# Altium schematic sheet ContollerRMC.SchDoc
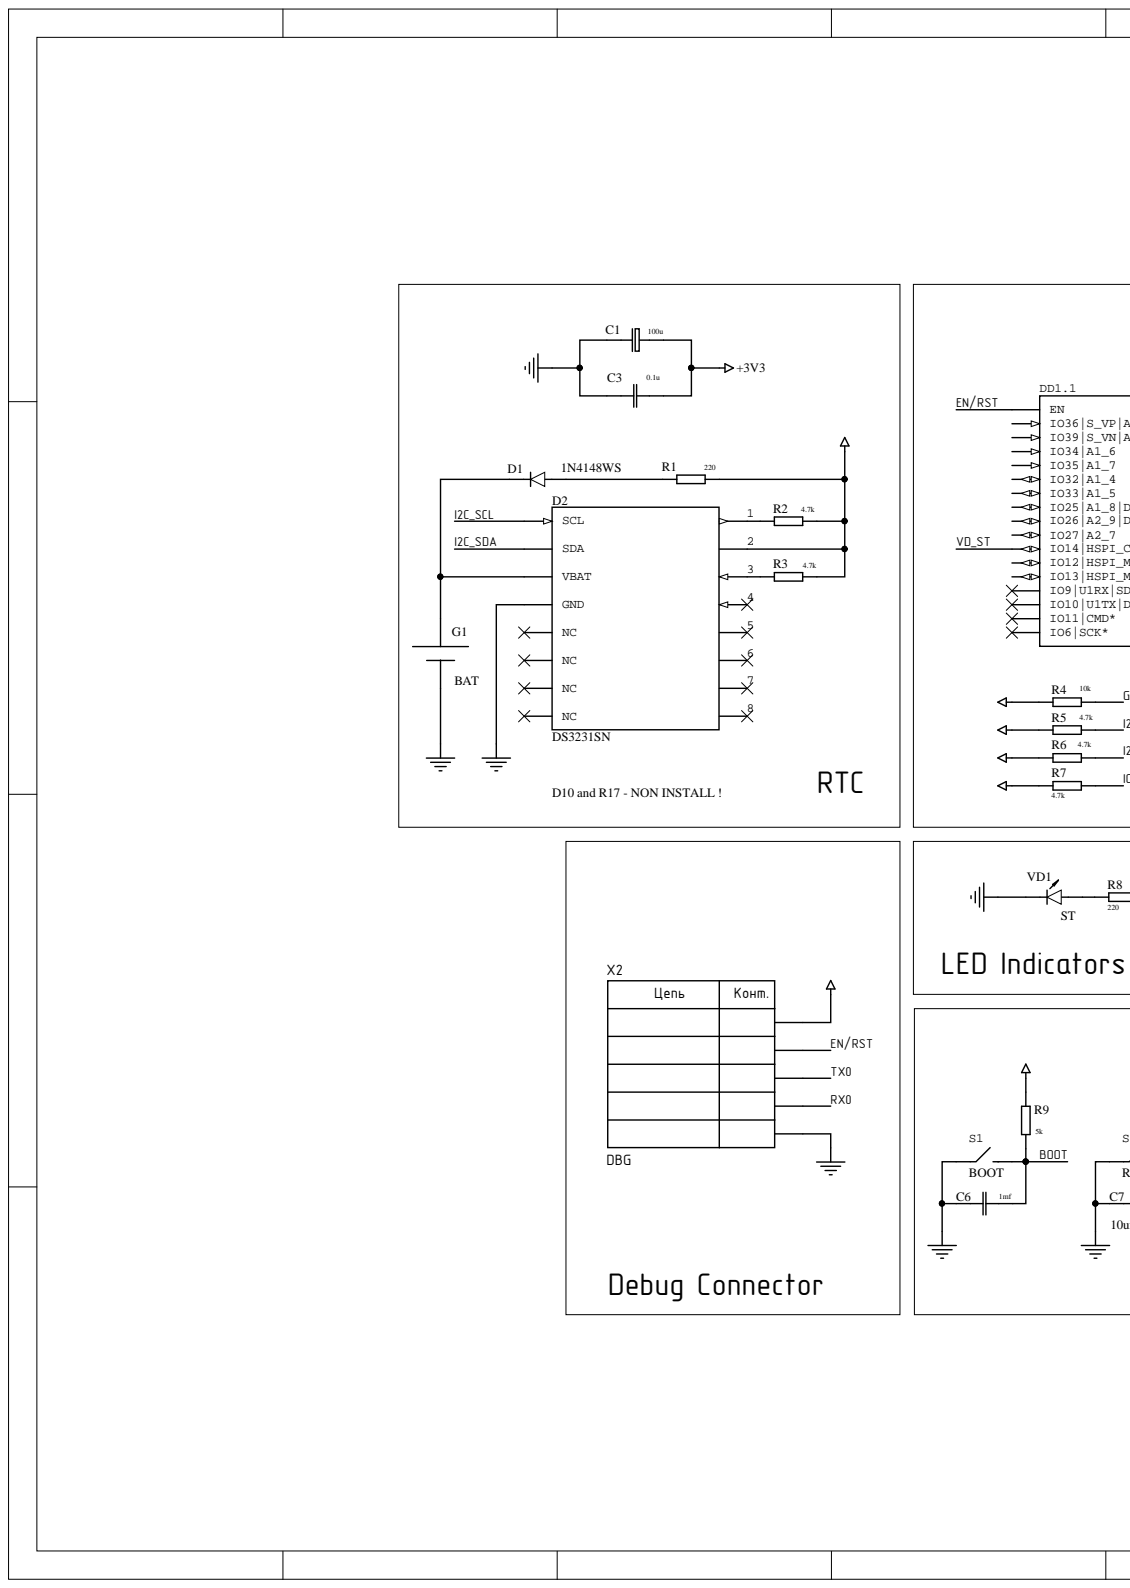
[diagram p0: ContollerRMC.SchDoc, left]
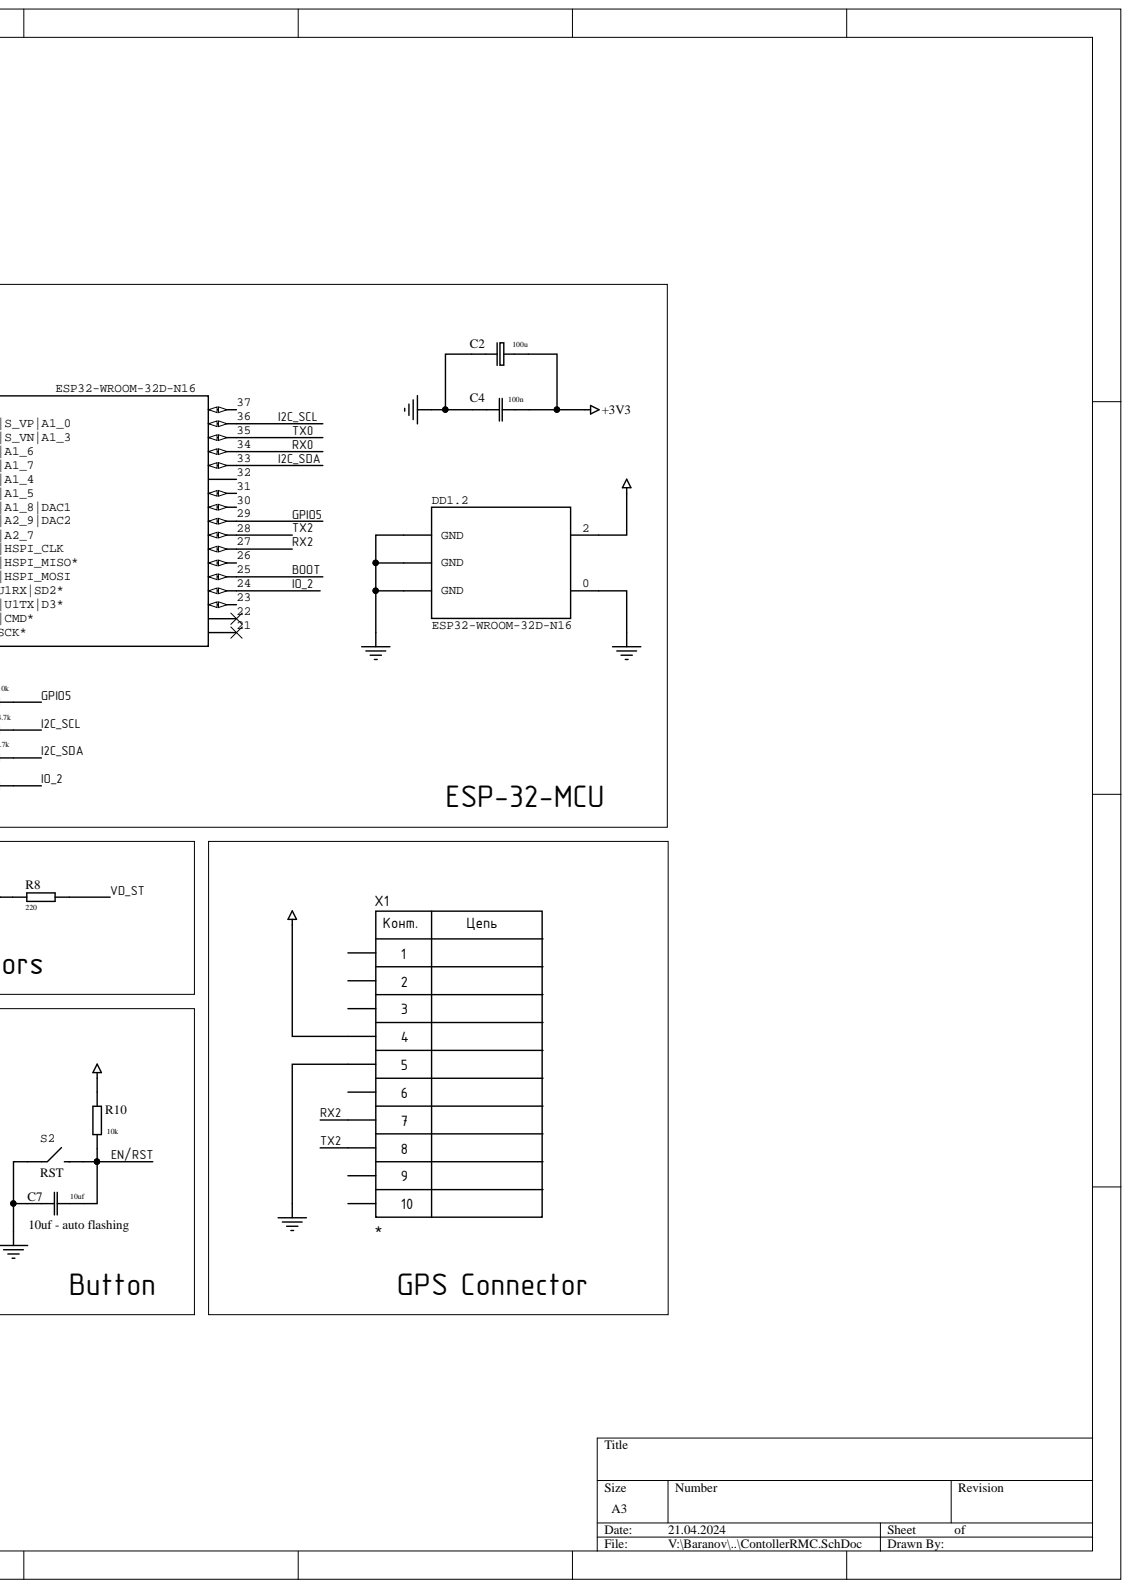
[diagram p1: ContollerRMC.SchDoc, right]

## Parts
C1  100u  [EL Case C (6032-28)]
C2  100u  [EL Case C (6032-28)]
C3  0.1u  [C0805(2013)]
C4  100n  [C1206(3216)]
C6  1mf  [C0805(2013)]
C7  10uf  [C0805(2013)]
D1  1N4148WS  [SOD323]
D2  DS3231SN  [SO16-300]
DD1  PartNumber: ESP32-WROOM-32D-N16  [ESP32-WROOM-32D]  (hidden comment: ValueManufacturerPartNumber)  (parts .1,.2)
G1  BAT  [BAT: BTH-R36-20]
R1  220  [R0805]
R2  4.7k  [R0805]
R3  4.7k  [R0805]
R4  10k  [R0805]
R5  4.7k  [R0805]
R6  4.7k  [R0805]
R7  4.7k  [R0805]
R8  220  [R0805]
R9  5k  [R0805]
R10  10k  [R0805]
S1  BOOT  [BUT_SKRPACE010]
S2  RST  [BUT_SKRPACE010]
VD1  ST  [Led 0805-Blue]
X1  (no value)  [CON: PLS-10 - 2.0mm]
X2  DBG  [CON: PLS-5]

## Nets
+3V3: C1.1 C2.1 C3.2 C4.1 D2.2 DD1.2 R1.1 R2.2 R3.2 R4.1 R5.1 R6.1 R7.2 R9.1 R10.1 X1.4 X2.1
GND: C1.2 C2.2 C3.1 C4.2 C6.2 C7.2 D2.13(GND) DD1.0 DD1.1(GND) DD1.15(GND) DD1.38(GND) G1.2 S1.1 S2.1 VD1.2 X1.5 X2.5
BOOT: C6.1 DD1.25 R9.2 S1.2
EN/RST: C7.1 DD1.3(EN) R10.2 S2.2 X2.2
GPIO5: DD1.29 R4.2
I2C_SCL: D2.16(SCL) DD1.36 R5.2
I2C_SDA: D2.15(SDA) DD1.33 R6.2
IO_2: DD1.24 R7.1
RX0: DD1.34 X2.4
RX2: DD1.27 X1.7
TX0: DD1.35 X2.3
TX2: DD1.28 X1.8
VD_ST: DD1.13(IO14|HSPI_CLK) R8.2
NetD1_1: D1.1 R1.2
NetD1_2: D1.2 D2.14(VBAT) G1.1
NetD2_1: D2.1 R2.1
NetD2_3: D2.3 R3.1
NetR8_1: R8.1 VD1.1

Not connected: D2 4 5 6 7 8 9(NC) 10(NC) 11(NC) 12(NC); DD1 4(IO36|S_VP|A1_0) 5(IO39|S_VN|A1_3) 6(IO34|A1_6) 7(IO35|A1_7) 8(IO32|A1_4) 9(IO33|A1_5) 10(IO25|A1_8|DAC1) 11(IO26|A2_9|DAC2) 12(IO27|A2_7) 14(IO12|HSPI_MISO*) 16(IO13|HSPI_MOSI) 17(IO9|U1RX|SD2*) 18(IO10|U1TX|D3*) 19(IO11|CMD*) 20(IO6|SCK*) 21 22 23 26 30 31 32 37; X1 1 2 3 6 9 10

## Library data of the parts
C1 C2 = 100u-6.3V: TECAP, 100 мкФ, 6.3 В, тип C, 10%; Manufacturer: Китай; PartNumber: TECAP100/6,3VC, 10%; Component Kind: Standard; HelpURL: https://www.chipdip.ru/product/tecap-100-mkf-6.3-v-tip-c-10; Package: 3.2 x 6 mm (LxW); Signal Integrity: C; Simulation: C; Value: 100u; Library Name: AECorpLB.IntLib
C3 C6 C7 = C-0805: SMD-0805; Component Kind: Standard; Price: 0.014; Signal Integrity: Cap; Simulation: Capacitor; Library Name: AECorpLB.IntLib
  C3: Value: 0.1u
  C6: Value: 1mf
  C7: Value: 10uf
C4 = C-1206   50V 100n: SMD-1206 50V Y5V +80/-20%; Component Kind: Standard; Price: 0.085; Signal Integrity: Cap; Simulation: Capacitor; Value: 100n; Library Name: AECorpLB.IntLib
D1 = 1N4148WS: SOD-323 100V 150mA; Component Kind: Standard; Price: 0.02; Library Name: AECorpLB.IntLib
D2 = DS3231SN: I2C real-time clock (RTC) Integrated  RTC/TCXO/Crystal; MF: Maxim Integrated Products; MP: DS3231; Component Kind: Standard; Datasheet: https://static.chipdip.ru/lib/859/DOC003859694.pdf; Digi-Key_Part_Number: DS3231SN#T&R; Digi-Key_Purchase_URL: https://www.digikey.hk/product-detail/en/ohmite/FCSL76R005FER/FCSL76R005FERCT-ND/1853780?utm_source=snapeda&utm_medium=aggregator&utm_campaign=symbol; Package: SO16; Library Name: AECorpLB.IntLib
DD1 = ESP32 - WROOM - 32U: ESP32-D0WD, Wi-Fi, BLE, 80 - 240MHz; Manufacturer: Espressif; Bluetooth: v4.2 BR/EDR и BLE; Flash size: 16 МБ; Interface: GPIO, SD, UART, SPI, SDIO, I2C, I2S, IR; PowerVCC: 2.7...3.6; WiFi: 802.11 b/g/n (802.11n до 150 Мбит/с); Component Kind: Standard; Datasheet: https://static.chipdip.ru/lib/789/DOC015789371.pdf; HelpURL: https://www.studiopieters.nl/esp32-pinout/; Library Name: AECorpLB.IntLib
G1 = BATCR1220: BATTERY HOLDER COIN CR1220; MP: BH1220T-C-G; Component Kind: Standard; Library Name: AECorpLB.IntLib
R1-R10 = R-0805: SMD 0805 0.1W 5% 150V; Component Kind: Standard; Price: 0.017; Signal Integrity: Res; Simulation: Resistor; Library Name: AECorpLB.IntLib
  R1 R8: Value: 220
  R2 R3 R5-R7: Value: 4.7k
  R4 R10: Value: 10k
  R9: Value: 5k
S1 S2 = Button SMD 3х4х2.5: SMD Button, 3х4х2.5 mm, 24VDC, 50mA; Manufacturer: ALPS; PartNumber: SKRPACE010; Component Kind: Standard; Component Type: Кнопка тактовая SMD; Datasheet: https://static.chipdip.ru/lib/915/DOC041915396.pdf; Package: 3*4*2.5; Library Name: AECorpLB.IntLib
VD1 = Led 0805 SMD 2013. TO-2013BC-BF: BLUE 247мКд, 120°, 468нМ; Color: Blue; Component Kind: Standard; Datasheet: https://static.chipdip.ru/lib/736/DOC002736050.pdf; Price: 0.09; Library Name: AECorpLB.IntLib
X1 = 10-Connector: Component Kind: Standard; Library Name: AECorpLB.IntLib
X2 = 5-Connector: Component Kind: Standard; Model: DBG; Library Name: AECorpLB.IntLib
